# Altium schematic sheet RaspberryHat.SchDoc
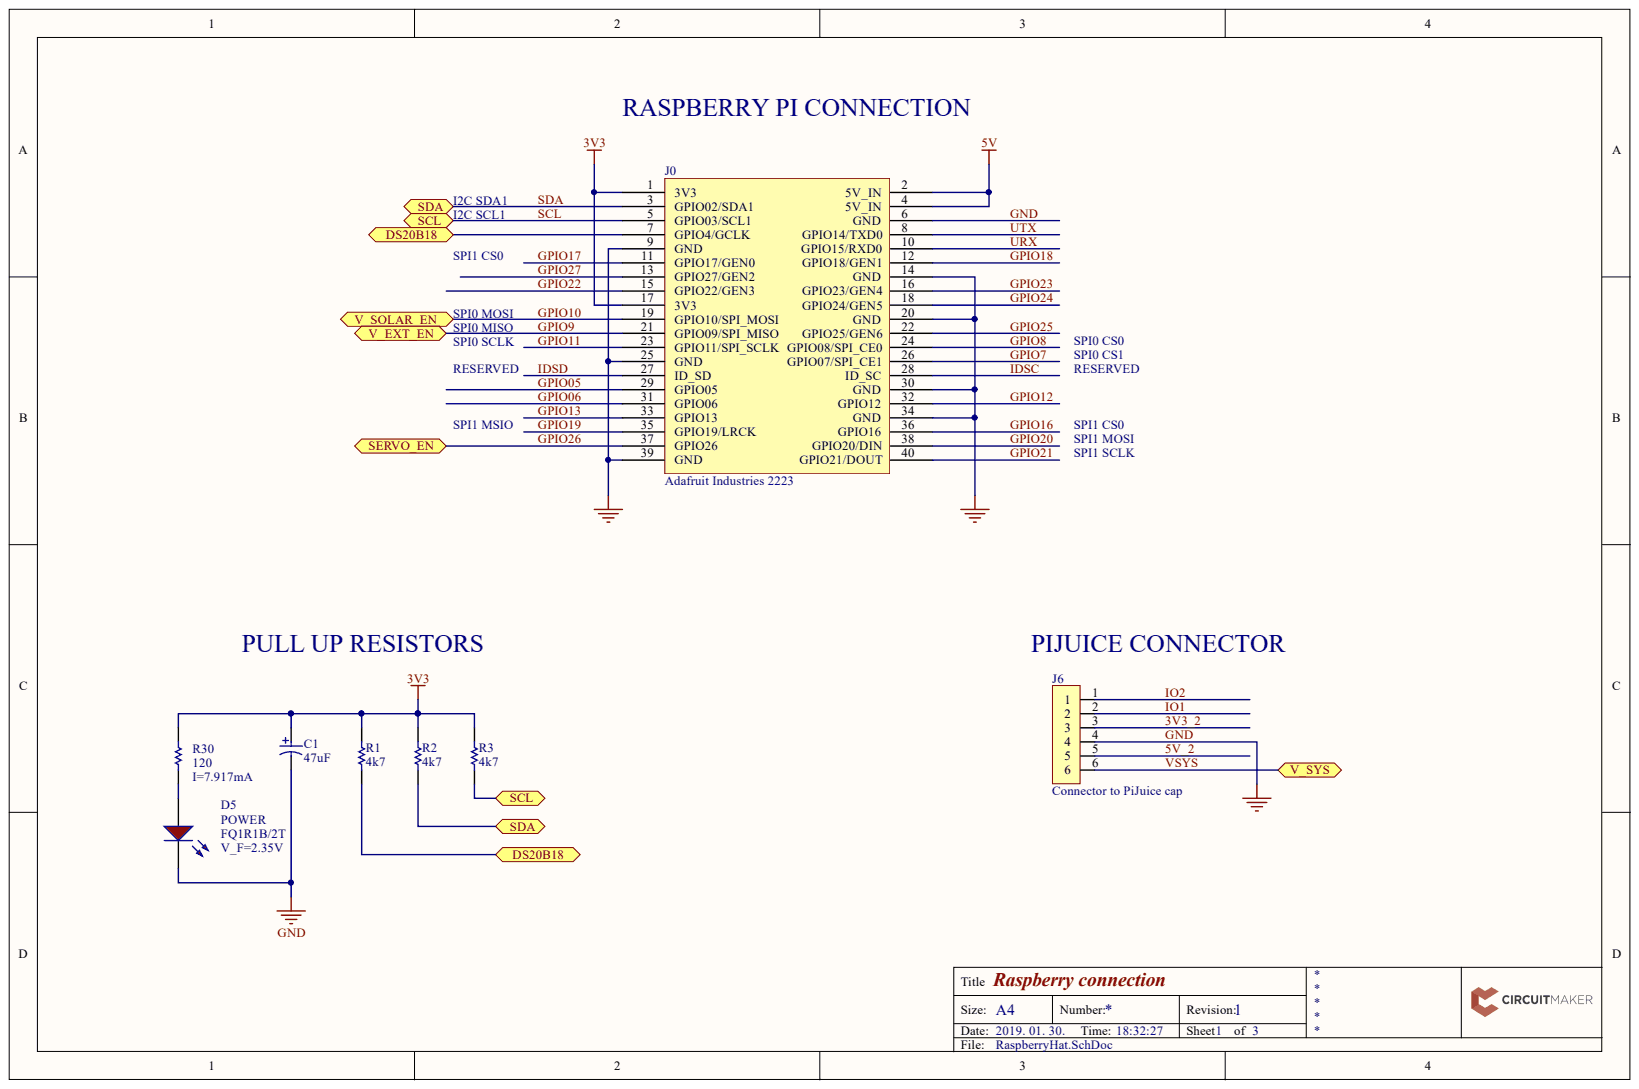
[diagram p0: RaspberryHat.SchDoc]

## Parts
C1  47uF  [PNSC-FT-V-53x53-2_V]
D5  POWER  [PCB-j4n23iwtpnxz235ppza8-1]
J0  Adafruit Industries 2223  [PCB-dv9vmhrfa2chsb9gidwm-1]
J6  Connector to PiJuice cap  [PCB-oudem42uhcimrbfznktg-1]
R1  4k7  [ERJ-8ENF1001V]
R2  4k7  [ERJ-8ENF1001V]
R3  4k7  [ERJ-8ENF1001V]
R30  120  [ERJ-8ENF1001V]

## Ports
DS20B18, SCL, SDA, SERVO_EN, V_EXT_EN, V_SOLAR_EN, V_SYS

## Nets
3V3: C1.1 J0.1(3V3) J0.17(3V3) R1.1 R2.1 R3.1 R30.2
5V: J0.2(5V_IN) J0.4(5V_IN)
3V3_2: J6.3
5V_2: J6.5
DS20B18: J0.7(GPIO4/GCLK) R1.2 ; port DS20B18
GND: C1.2 D5.2 J0.6(GND) J0.9(GND) J0.14(GND) J0.20(GND) J0.25(GND) J0.30(GND) J0.34(GND) J0.39(GND) J6.4
GPIO05: J0.29(GPIO05)
GPIO06: J0.31(GPIO06)
GPIO7: J0.26(GPIO07/SPI_CE1)
GPIO8: J0.24(GPIO08/SPI_CE0)
GPIO9: J0.21(GPIO09/SPI_MISO) ; port V_EXT_EN
GPIO10: J0.19(GPIO10/SPI_MOSI) ; port V_SOLAR_EN
GPIO11: J0.23(GPIO11/SPI_SCLK)
GPIO12: J0.32(GPIO12)
GPIO13: J0.33(GPIO13)
GPIO16: J0.36(GPIO16)
GPIO17: J0.11(GPIO17/GEN0)
GPIO18: J0.12(GPIO18/GEN1)
GPIO19: J0.35(GPIO19/LRCK)
GPIO20: J0.38(GPIO20/DIN)
GPIO21: J0.40(GPIO21/DOUT)
GPIO22: J0.15(GPIO22/GEN3)
GPIO23: J0.16(GPIO23/GEN4)
GPIO24: J0.18(GPIO24/GEN5)
GPIO25: J0.22(GPIO25/GEN6)
GPIO26: J0.37(GPIO26) ; port SERVO_EN
GPIO27: J0.13(GPIO27/GEN2)
IDSC: J0.28(ID_SC)
IDSD: J0.27(ID_SD)
IO1: J6.2
IO2: J6.1
SCL: J0.5(GPIO03/SCL1) R3.2 ; port SCL
SDA: J0.3(GPIO02/SDA1) R2.2 ; port SDA
URX: J0.10(GPIO15/RXD0)
UTX: J0.8(GPIO14/TXD0)
VSYS: J6.6 ; port V_SYS
NetD5_1: D5.1 R30.1

## Library data of the parts
C1 = CMP-96011-3: Cap Aluminum Lytic 47uF 25V 20% (5 X 5.8mm) SMD 0.36 Ohm 240mA 2000h 105?C Automotive T/R; Manufacturer Part Number: EEE-FT1E470AR; Capacitance: 47.0 µF; Dielectric Material: Aluminum Electrolytic; Equivalent Series Resistance (ESR): 360 mΩ; Lead-Free Status: Lead Free; Voltage Rating (DC): 25.0 V; Capacitance Tolerance: ±20%; Component Kind: Standard; Library Name: CommunityVault; Number of Pins: 2; OctopartID: 492d8115795c96ea; Octopart URL: https://octopart.com/eee-ft1e470ar-panasonic-20059415; Operating Temperature: -55.0 °C to 105 °C; Packaging: Tape & Reel (TR), Cut Tape (CT); RoHS: Compliant; Size-Diameter: 5.00 mm; Size-Height: 5.80 mm
D5 = CMP-50699963429b8fae-3: Red 3.2 x 1.6 mm 1206 160° Water Clear 6 mcd 2.2 V PCB Surface Mount LED; : V_F=2.35V; : FQ1R1B/2T; Component Kind: Standard; Library Name: CommunityVault; Size-Length: 3.20 mm
J0 = CMP-4e918466a73d9156-14: GPIO Stacking Header for Pi A+/B+/Pi 2/Pi 3 - Extra-long 2x20 Pins; Component Kind: Standard; Library Name: CommunityVault
J6 = CMP-7ab1b1e1ad8ca576-10: MTA-100 Series 6 Position 2.54 mm Pitch Single Row Tin Plated Header; ELV Compliant: Compliant; Number of Contacts: 6; Pin Pitch: 2.54 mm; Component Kind: Standard; Library Name: CommunityVault; Mounting Style: Through Hole, Vertical; OctopartID: 7ab1b1e1ad8ca576; REACH SVHC Compliance: No SVHC
R1-R3 R30 = CMP-3663831-4: Res Thick Film 3.2 x 1.6 mm 1K Ohm 1% 0.25W(1/4W) 100ppm/ C Molded SMD Punched Carrier T/R; Power Rating: 250 mW; Resistance: 1.00 kΩ; Component Kind: Standard; Library Name: CommunityVault; Lifecycle Status: Not Listed by Manufacturer; Manufacturer Part Number: ERJ-8ENF1001V; Mounting Style: Surface Mount; Number of Pins: 2; OctopartID: 229be8dcb721fd5c; Octopart URL: http://octopart.com/erj-8enf1001v-panasonic-55423196; Packaging: Cut Tape (CT), Tape & Reel (TR); Resistance Tolerance: ±1%; RoHS: Compliant; Size-Height: 600 µm; Size-Length: 2.00 mm; Size-Width: 1.60 mm
  R30: : I=7.917mA
